# Altium schematic sheet ZYNQ_USB_PHY.SchDoc(ZYNQ_USB_PHY)
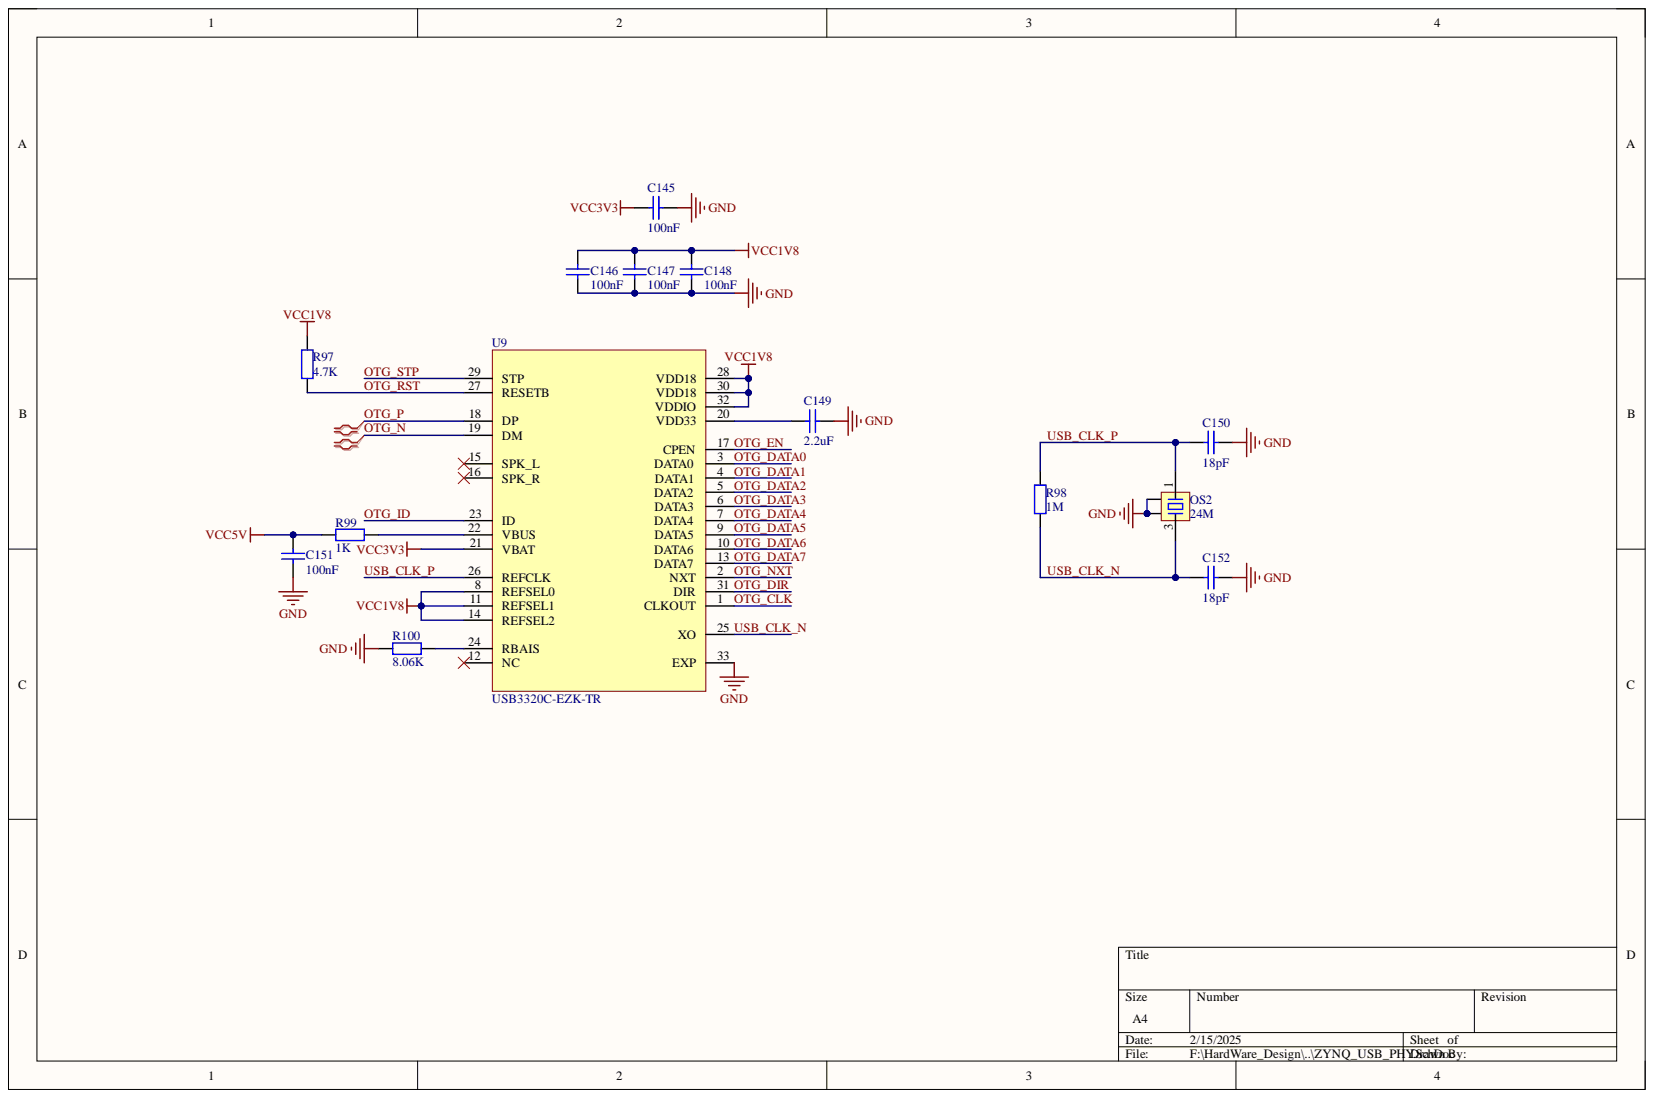
[diagram p0: ZYNQ_USB_PHY.SchDoc]

## Parts
C145  100nF  [C 0402_L]
C146  100nF  [C 0402_L]
C147  100nF  [C 0402_L]
C148  100nF  [C 0402_L]
C149  2.2uF  [C 0402_L]
C150  18pF  [C 0402_L]
C151  100nF  [C 0402_L]
C152  18pF  [C 0402_L]
OS2  24M  [OSC 3225-4P]
R97  4.7K  [R 0402_L]
R98  1M  [R 0402_L]
R99  1K  [R 0402_L]
R100  8.06K  [R 0402_L]
U9  USB3320C-EZK-TR  [QFN50P500X500X100-33N-D]

## Nets
GND: C145.1 C146.1 C147.1 C148.1 C149.2 C150.2 C151.1 C152.2 OS2.2 OS2.4 R100.1 U9.33(EXP)
VCC1V8: C146.2 C147.2 C148.2 R97.2 U9.8(REFSEL0) U9.11(REFSEL1) U9.14(REFSEL2) U9.28(VDD18) U9.30(VDD18) U9.32(VDDIO)
VCC3V3: C145.2 U9.21(VBAT)
VCC5V: C151.2 R99.1
OTG_CLK: U9.1(CLKOUT)
OTG_DATA0: U9.3(DATA0)
OTG_DATA1: U9.4(DATA1)
OTG_DATA2: U9.5(DATA2)
OTG_DATA3: U9.6(DATA3)
OTG_DATA4: U9.7(DATA4)
OTG_DATA5: U9.9(DATA5)
OTG_DATA6: U9.10(DATA6)
OTG_DATA7: U9.13(DATA7)
OTG_DIR: U9.31(DIR)
OTG_EN: U9.17(CPEN)
OTG_ID: U9.23(ID)
OTG_N: U9.19(DM)
OTG_NXT: U9.2(NXT)
OTG_P: U9.18(DP)
OTG_RST: R97.1 U9.27(RESETB)
OTG_STP: U9.29(STP)
USB_CLK_N: C152.1 OS2.3 R98.1 U9.25(XO)
USB_CLK_P: C150.1 OS2.1 R98.2 U9.26(REFCLK)
NetC149_1: C149.1 U9.20(VDD33)
NetR99_2: R99.2 U9.22(VBUS)
NetR100_2: R100.2 U9.24(RBAIS)

Not connected: U9 12(NC) 15(SPK_L) 16(SPK_R)

## Library data of the parts
C145-C149 C151 = Cap: 贴片电容; Component Kind: Standard; Library Name: A_Schlib.SchLib
  C145-C148 C151: value: 100nF
  C149: value: 2.2uF
C150 C152 = Cap1: Component Kind: Standard; value: 18pF; Library Name: 零散元件库.SCHLIB
OS2 = XTAL-4P: 4脚无源晶振; 版权归属: 封装库来自淘宝卖家 ID：黄晶宝石; BOM名称: 4脚无源晶振; Component Kind: Standard; Library Name: A_Schlib.SchLib; 学习资源: https://shop463601444.taobao.com
R97-R100 = Res: 通用; Component Kind: Standard; Library Name: A_Schlib.SchLib
  R97: value: 4.7K
  R98: value: 1M
  R99: value: 1K
  R100: value: 8.06K
U9 = USB3320C-EZK-TR: USB-PHY芯片; Component Kind: Standard; Library Name: A_Schlib.SchLib
